# Altium schematic sheet MIXR Input System - Buffer.SchDoc
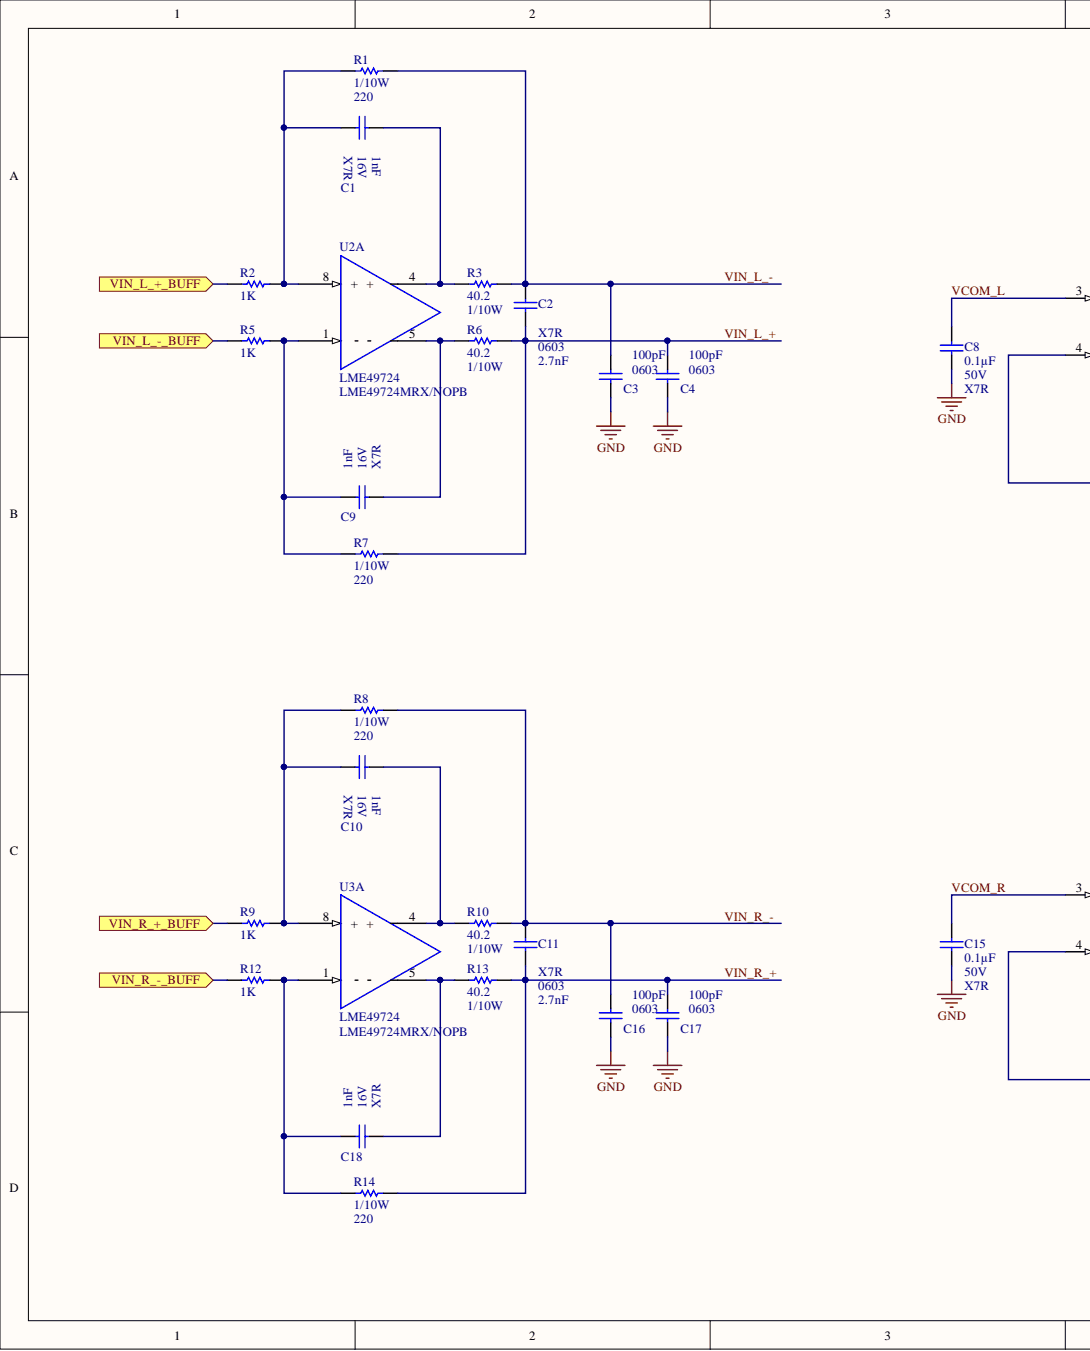
[diagram p0: MIXR Input System - Buffer.SchDoc, left]
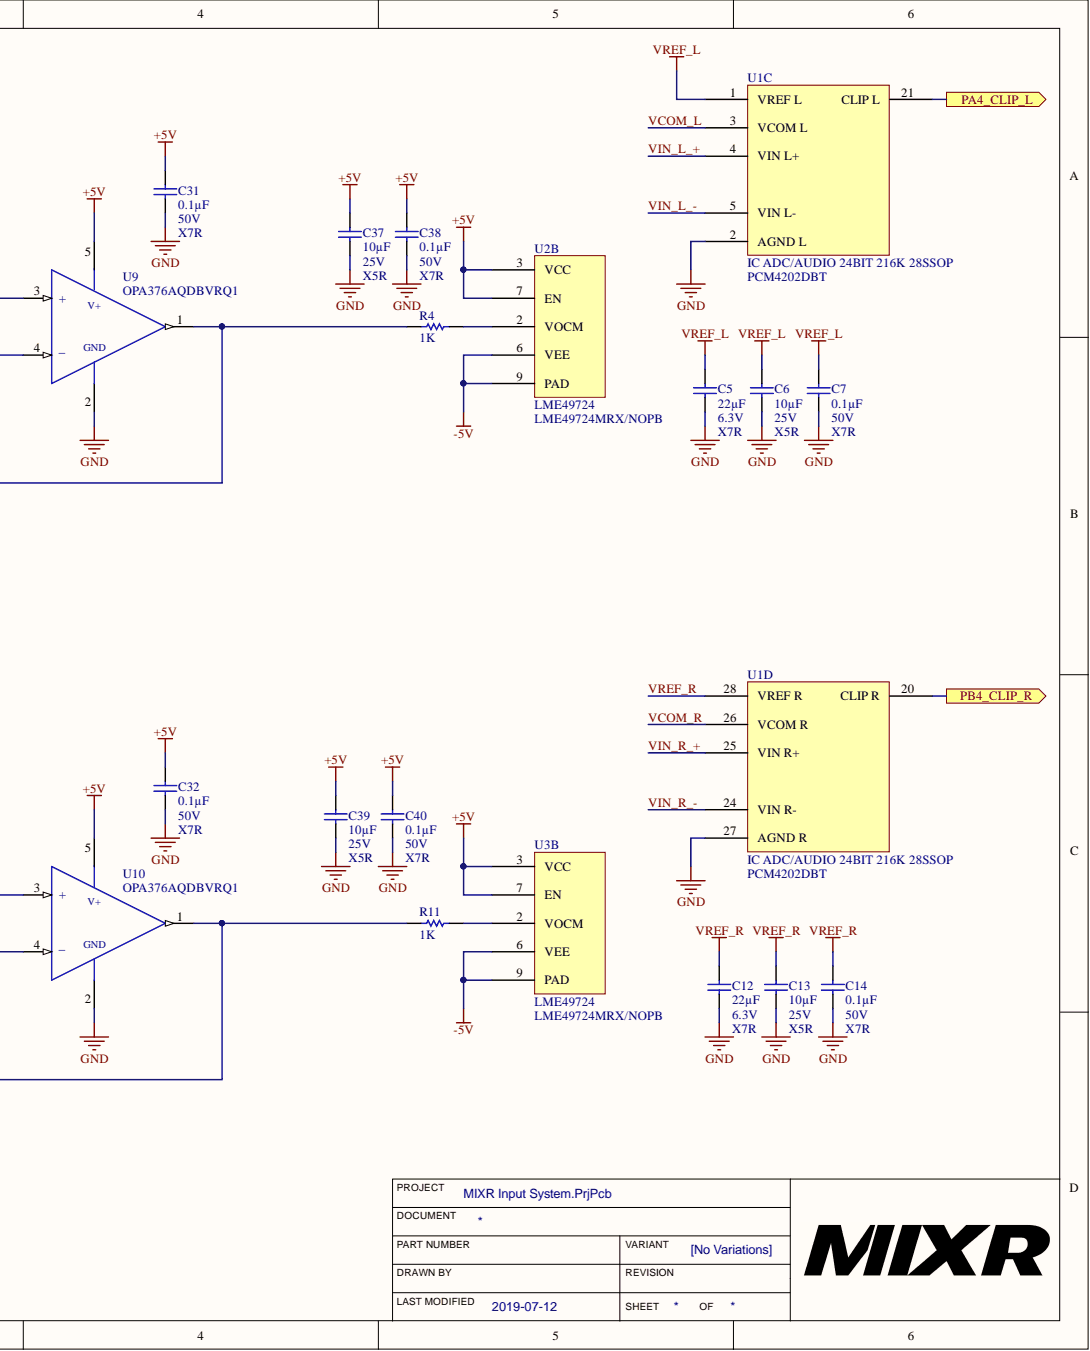
[diagram p1: MIXR Input System - Buffer.SchDoc, right]

## Parts
C1  CAP CER 1NF 16V 10% X7R 0603  [CAP, 0603]  (value not drawn)
C2  Capacitance: 2.7nF  Case/Package: 0603  Dielectric: X7R  [CAP, 0603]  (hidden comment: CAP CER 2700PF 50V X7R 0603)
C3  CAP CER 100PF 50V C0G/NP0 0603  [CAP, 0603]  (value not drawn)
C4  Capacitance: 100pF  Case/Package: 0603  [CAP, 0603]  (hidden comment: CAP CER 100PF 50V C0G/NP0 0603)
C5  Capacitance: 22µF  Temperature Coefficient: X7R  Voltage: 6.3V  [CAP, 1206]  (hidden comment: CAP CER 22UF 6.3V ±10% X7R 1206)
C6  Capacitance: 10µF  Temperature Coefficient: X5R  Voltage: 25V  [CAP, 0603]  (hidden comment: CAP CER 10uF 25V 20% X5R 0603)
C7  Capacitance: 0.1µF  Temperature Coefficient: X7R  Voltage: 50V  [CAP, 0603]  (hidden comment: CAP CER 0.1UF 50V 10% X7R 0603)
C8  Capacitance: 0.1µF  Temperature Coefficient: X7R  Voltage: 50V  [CAP, 0603]  (hidden comment: CAP CER 0.1UF 50V 10% X7R 0603)
C9  CAP CER 1NF 16V 10% X7R 0603  [CAP, 0603]  (value not drawn)
C10  CAP CER 1NF 16V 10% X7R 0603  [CAP, 0603]  (value not drawn)
C11  Capacitance: 2.7nF  Case/Package: 0603  Dielectric: X7R  [CAP, 0603]  (hidden comment: CAP CER 2700PF 50V X7R 0603)
C12  Capacitance: 22µF  Temperature Coefficient: X7R  Voltage: 6.3V  [CAP, 1206]  (hidden comment: CAP CER 22UF 6.3V ±10% X7R 1206)
C13  Capacitance: 10µF  Temperature Coefficient: X5R  Voltage: 25V  [CAP, 0603]  (hidden comment: CAP CER 10uF 25V 20% X5R 0603)
C14  Capacitance: 0.1µF  Temperature Coefficient: X7R  Voltage: 50V  [CAP, 0603]  (hidden comment: CAP CER 0.1UF 50V 10% X7R 0603)
C15  Capacitance: 0.1µF  Temperature Coefficient: X7R  Voltage: 50V  [CAP, 0603]  (hidden comment: CAP CER 0.1UF 50V 10% X7R 0603)
C16  CAP CER 100PF 50V C0G/NP0 0603  [CAP, 0603]  (value not drawn)
C17  Capacitance: 100pF  Case/Package: 0603  [CAP, 0603]  (hidden comment: CAP CER 100PF 50V C0G/NP0 0603)
C18  CAP CER 1NF 16V 10% X7R 0603  [CAP, 0603]  (value not drawn)
C31  Capacitance: 0.1µF  Voltage: 50V  [CAP, 0603]  (hidden comment: CAP CER 0.1UF 50V 10% X7R 0603)
C32  Capacitance: 0.1µF  Voltage: 50V  [CAP, 0603]  (hidden comment: CAP CER 0.1UF 50V 10% X7R 0603)
C37  Capacitance: 10µF  Temperature Coefficient: X5R  Voltage: 25V  [CAP, 0603]  (hidden comment: CAP CER 10uF 25V 20% X5R 0603)
C38  Capacitance: 0.1µF  Temperature Coefficient: X7R  Voltage: 50V  [CAP, 0603]  (hidden comment: CAP CER 0.1UF 50V 10% X7R 0603)
C39  Capacitance: 10µF  Temperature Coefficient: X5R  Voltage: 25V  [CAP, 0603]  (hidden comment: CAP CER 10uF 25V 20% X5R 0603)
C40  Capacitance: 0.1µF  Temperature Coefficient: X7R  Voltage: 50V  [CAP, 0603]  (hidden comment: CAP CER 0.1UF 50V 10% X7R 0603)
R1  Power (Watts): 1/10W  [RES, 0603]  (hidden comment: RES 220 OHM 1% 1/10W 0603)
R2  1K  [RES, 0603]
R3  Resistance: 40.2  [RES, 0603]  (hidden comment: RES 40.2 OHM 0.5% 1/10W 0603)
R4  1K  [RES, 0603]
R5  1K  [RES, 0603]
R6  Power: 1/10W  Resistance: 40.2  [RES, 0603]  (hidden comment: RES 40.2 OHM 0.5% 1/10W 0603)
R7  Power (Watts): 1/10W  [RES, 0603]  (hidden comment: RES 220 OHM 1% 1/10W 0603)
R8  Power (Watts): 1/10W  [RES, 0603]  (hidden comment: RES 220 OHM 1% 1/10W 0603)
R9  1K  [RES, 0603]
R10  Resistance: 40.2  [RES, 0603]  (hidden comment: RES 40.2 OHM 0.5% 1/10W 0603)
R11  1K  [RES, 0603]
R12  1K  [RES, 0603]
R13  Power: 1/10W  Resistance: 40.2  [RES, 0603]  (hidden comment: RES 40.2 OHM 0.5% 1/10W 0603)
R14  Power (Watts): 1/10W  [RES, 0603]  (hidden comment: RES 220 OHM 1% 1/10W 0603)
U1  IC ADC/AUDIO 24BIT 216K 28SSOP  Manufacturer Part Number: PCM4202DBT  [IC ADC 24 BIT AUDIO 216 KHZ SSOP-28]  (parts C,D)
U2  LME49724  Manufacturer Part Number: LME49724MRX/NOPB  [IC OP AMP AUDIO LME49724 8-SOIC]  (parts A,B)
U3  LME49724  Manufacturer Part Number: LME49724MRX/NOPB  [IC OP AMP AUDIO LME49724 8-SOIC]  (parts A,B)
U9  Manufacturer Part Number: OPA376AQDBVRQ1  [IC OP AMP GP RR 10MHZ SOT-23-5]  (hidden comment: IC OP AMP GEN PURPOSE RR 5.5MHZ SOT-23-5)
U10  Manufacturer Part Number: OPA376AQDBVRQ1  [IC OP AMP GP RR 10MHZ SOT-23-5]  (hidden comment: IC OP AMP GEN PURPOSE RR 5.5MHZ SOT-23-5)

## Ports
PA4_CLIP_L, PB4_CLIP_R, VIN_L_+_BUFF, VIN_L_-_BUFF, VIN_R_+_BUFF, VIN_R_-_BUFF

## Nets
+5V: C31.1 C32.1 C37.1 C38.1 C39.1 C40.1 U2.3(VCC) U2.7(EN) U3.3(VCC) U3.7(EN) U9.5 U10.5
-5V: U2.6(VEE) U2.9(PAD) U3.6(VEE) U3.9(PAD)
GND: C3.2 C4.2 C5.2 C6.2 C7.2 C8.2 C12.2 C13.2 C14.2 C15.2 C16.2 C17.2 C31.2 C32.2 C37.2 C38.2 C39.2 C40.2 U1.2(AGND_L) U1.27(AGND_R) U9.2 U10.2
VREF_L: C5.1 C6.1 C7.1 U1.1(VREF_L)
PA4_CLIP_L: U1.21(CLIP_L) ; port PA4_CLIP_L
PB4_CLIP_R: U1.20(CLIP_R) ; port PB4_CLIP_R
VCOM_L: C8.1 U1.3(VCOM_L) U9.3(+)
VCOM_R: C15.1 U1.26(VCOM_R) U10.3(+)
VIN_L_+: C2.2 C4.1 R6.2 R7.1 U1.4(VIN_L+)
VIN_L_-: C2.1 C3.1 R1.2 R3.2 U1.5(VIN_L-)
VIN_R_+: C11.2 C17.1 R13.2 R14.1 U1.25(VIN_R+)
VIN_R_-: C11.1 C16.1 R8.2 R10.2 U1.24(VIN_R-)
VREF_R: C12.1 C13.1 C14.1 U1.28(VREF_R)
NetC1_1: C1.1 R3.1 U2.4(+)
NetC1_2: C1.2 R1.1 R2.2 U2.8(+)
NetC9_1: C9.1 R5.2 R7.2 U2.1
NetC9_2: C9.2 R6.1 U2.5
NetC10_1: C10.1 R10.1 U3.4(+)
NetC10_2: C10.2 R8.1 R9.2 U3.8(+)
NetC18_1: C18.1 R12.2 R14.2 U3.1
NetC18_2: C18.2 R13.1 U3.5
NetR2_1: R2.1
NetR4_1: R4.1 U9.1 U9.4
NetR4_2: R4.2 U2.2(VOCM)
NetR5_1: R5.1
NetR9_1: R9.1
NetR11_1: R11.1 U10.1 U10.4
NetR11_2: R11.2 U3.2(VOCM)
NetR12_1: R12.1

## Library data of the parts
C1 C9 C10 C18 = CAP CER 1NF 16V 10% X7R 0603: 1000pF ±10% 16V Ceramic Capacitor X7R 0603 (1608 Metric); Manufacturer: KEMET; Manufacturer Part Number: C0603C102K4RECAUTO; Component Kind: Standard; ComponentLink1Description: https://api.kemet.com/component-edge/download/datasheet/C0603C102K4RECAUTO.pdf?src-supplier=Digi-Key; ComponentLink1URL: https://api.kemet.com/component-edge/download/datasheet/C0603C102K4RECAUTO.pdf?src-supplier=Digi-Key; Pricing 1: 1 = 0.19000, 10 = 0.13200, 100 = 0.06210, 500 = 0.04356, 1000 = 0.03606 (USD); RoHS: No; Stock 1: 7692; Supplier 1: Digi-Key; Supplier Part Number 1: 399-17878-1-ND; Library Name: Schematic Diagrams.SchLib
C2 C11 = CAP CER 2700PF 50V X7R 0603: 2700pF ±10% 50V Ceramic Capacitor X7R 0603 (1608 Metric); Manufacturer: KEMET; Manufacturer Part Number: C0603C272K5RACTU; Case Code (Imperial): 0603; Case Code (Metric): 1608; Component Kind: Standard; ComponentLink1Description: https://datasheet.ciiva.com/18192/getdatasheetpartid-321287-18192955.pdf?src-supplier=Verical; ComponentLink1URL: https://datasheet.ciiva.com/18192/getdatasheetpartid-321287-18192955.pdf?src-supplier=Verical; ComponentLink2Description: https://datasheet.ciiva.com/7823/1633156-7823726.pdf?src-supplier=Element14; ComponentLink2URL: https://datasheet.ciiva.com/7823/1633156-7823726.pdf?src-supplier=Element14; ComponentLink3Description: https://datasheet.ciiva.com/7823/1633156-7823727.pdf?src-supplier=Element14; ComponentLink3URL: https://datasheet.ciiva.com/7823/1633156-7823727.pdf?src-supplier=Element14; Depth: 0.8mm; Dielectric Material: Ceramic; Height: 0.8mm; Lead Pitch: 0.7mm; Length: 1.6mm; Max Operating Temperature: 125°C; Min Operating Temperature: -55°C; Mount: Surface Mount; Number of Pins: 2; Package Quantity: 1; Packaging: Tape and Reel; Pricing 1: 1 = 0.11000, 10 = 0.08200, 100 = 0.03660, 500 = 0.02614, 1000 = 0.02054 (USD); Radiation Hardening: No; REACH SVHC: No SVHC; RoHS: Yes; Stock 1: 166011; Supplier 1: Digi-Key; Supplier Part Number 1: 399-7890-1-ND; Termination: SMD/SMT; Thickness: 0.034inch; Tolerance: 10%; Voltage: 50V; Voltage Rating: 50V; Voltage Rating (DC): 50V; Width: 0.031inch; Library Name: Schematic Diagrams.SchLib
C3 C4 C16 C17 = CAP CER 100PF 50V C0G/NP0 0603: 100pF ±1% 50V Ceramic Capacitor C0G, NP0 0603 (1608 Metric); Manufacturer: Kyocera AVX; Manufacturer Part Number: 06035A101FAT2A; Case Code (Imperial): 0603; Case Code (Metric): 1608; Component Kind: Standard; ComponentLink1Description: https://datasheet.ciiva.com/22682/1796416-22682854.pdf; ComponentLink1URL: https://datasheet.ciiva.com/22682/1796416-22682854.pdf; ComponentLink2Description: https://datasheet.ciiva.com/150/ccog-150746.pdf; ComponentLink2URL: https://datasheet.ciiva.com/150/ccog-150746.pdf; ComponentLink3Description: https://datasheet.ciiva.com/150/ccog-150747.pdf; ComponentLink3URL: https://datasheet.ciiva.com/150/ccog-150747.pdf; ComponentLink4Description: https://datasheet.ciiva.com/150/ccog-150748.pdf; ComponentLink4URL: https://datasheet.ciiva.com/150/ccog-150748.pdf; ComponentLink5Description: https://datasheet.ciiva.com/28585/1796416-28585980.pdf; ComponentLink5URL: https://datasheet.ciiva.com/28585/1796416-28585980.pdf; ComponentLink6Description: https://datasheet.ciiva.com/16300/ccog-16300413.pdf; ComponentLink6URL: https://datasheet.ciiva.com/16300/ccog-16300413.pdf; ComponentLink7Description: https://datasheet.ciiva.com/17492/ccog-17492189.pdf; ComponentLink7URL: https://datasheet.ciiva.com/17492/ccog-17492189.pdf; Depth: 0.81mm; Dielectric: C0G; Height: 0.86mm; Lead Free: Lead Free; Length: 1.6mm; Max Operating Temperature: 125°C; Min Operating Temperature: -55°C; Mount: Surface Mount; Package Quantity: 1; Packaging: Tape and Reel; Pricing 1: 1=0.24, 10=0.161, 100=0.0814, 500=0.0557, 1000=0.0493 (USD); Radiation Hardening: No; REACH SVHC: No SVHC; Stock 1: 176069; Supplier 1: Digi-Key; Supplier Part Number 1: 478-6202-1-ND; Termination: SMD/SMT; Thickness: 0.035inch; Tolerance: 1%; Voltage: 50V; Voltage Rating: 50V; Voltage Rating (DC): 50V; Width: 0.81mm
  C3 C16: Capacitance: 100pF; Case/Package: 0603
C5 C12 = CAP CER 22UF 6.3V ±10% X7R 1206: 22µF ±10% 6.3V Ceramic Capacitor X7R 1206 (3216 Metric); Manufacturer 1: Murata Electronics North America; Manufacturer Part Number 1: GRM31CR70J226KE19L; Component Kind: Standard; ComponentLink1Description: http://www.murata.com/~/media/webrenewal/support/library/catalog/products/capacitor/mlcc/c02e.ashx; ComponentLink1URL: http://www.murata.com/~/media/webrenewal/support/library/catalog/products/capacitor/mlcc/c02e.ashx; Height - Seated (Max): -; Lead Spacing: -; Lead Style: -; Mounting Type: Surface Mount, MLCC; Operating Temperature: -55°C ~ 125°C; Package / Case: 1206 (3216 Metric); Packaging: Cut Tape (CT); Part Status: Active; Ratings: -; Size / Dimension: 0.126" L x 0.063" W (3.20mm x 1.60mm); Supplier 1: Digi-Key; Supplier Part Number 1: 490-6515-1-ND; Thickness (Max): 0.071" (1.80mm); Tolerance: ±10%
C6 C13 C37 C39 = CAP CER 10uF 25V 20% X5R 0603: 10µF ±20% 25V Ceramic Capacitor X5R 0603 (1608 Metric); Manufacturer 1: Murata Electronics North America; Manufacturer Part Number 1: GRM188R61E106MA73D; Component Kind: Standard; ComponentLink1Description: http://www.murata.com/~/media/webrenewal/support/library/catalog/products/capacitor/mlcc/c02e.ashx; ComponentLink1URL: http://www.murata.com/~/media/webrenewal/support/library/catalog/products/capacitor/mlcc/c02e.ashx; Height - Seated (Max): -; Lead Spacing: -; Lead Style: -; Mounting Type: Surface Mount, MLCC; Operating Temperature: -55°C ~ 85°C; Package / Case: 0603 (1608 Metric); Packaging: Cut Tape (CT); Part Status: Active; Ratings: -; Size / Dimension: 0.063" L x 0.031" W (1.60mm x 0.80mm); Supplier 1: Digi-Key; Supplier Part Number 1: 490-7202-1-ND; Thickness (Max): 0.031" (0.80mm); Tolerance: ±20%; Library Name: Schematic Diagrams.SchLib
C7 C8 C14 C15 C31 C32 C38 C40 = CAP CER 0.1UF 50V 10% X7R 0603: 0.10µF ±10% 50V Ceramic Capacitor X7R 0603 (1608 Metric); Manufacturer 1: AVX Corporation; Manufacturer Part Number 1: 06035C104KAT2A; Component Kind: Standard; ComponentLink1Description: http://datasheets.avx.com/X7RDielectric.pdf; ComponentLink1URL: http://datasheets.avx.com/X7RDielectric.pdf; Height - Seated (Max): -; Lead Spacing: -; Lead Style: -; Mounting Type: Surface Mount, MLCC; Operating Temperature: -55°C ~ 125°C; Package / Case: 0603 (1608 Metric); Packaging: Cut Tape (CT); Part Status: Active; Ratings: -; Size / Dimension: 0.063" L x 0.032" W (1.60mm x 0.81mm); Supplier 1: Digi-Key; Supplier Part Number 1: 478-5052-1-ND; Thickness (Max): 0.035" (0.90mm); Tolerance: ±10%; Library Name: Schematic Diagrams.SchLib
  C31 C32: Temperature Coefficient: X7R
R1 R7 R8 R14 = RES 220 OHM 1% 1/10W 0603: 220 Ohms ±1% 0.1W, 1/10W Chip Resistor 0603 (1608 Metric) Moisture Resistant Thick Film; Manufacturer: Yageo; Manufacturer Part Number: RC0603FR-07220RL; Resistance: 220; Temperature Coefficient: ±100ppm/°C; Category: Resistors; Component Kind: Standard; ComponentLink1Description: http://www.yageo.com/documents/recent/PYu-RC_Group_51_RoHS_L_8.pdf; ComponentLink1URL: http://www.yageo.com/documents/recent/PYu-RC_Group_51_RoHS_L_8.pdf; Composition: Thick Film; Failure Rate: -; Features: Moisture Resistant; Height - Seated (Max): 0.022" (0.55mm); Operating Temperature: -55°C ~ 155°C; Package / Case: 0603 (1608 Metric); Packaging: Cut Tape (CT); Part Status: Active; Pricing 1: 1=0.15, 10=0.022, 100=0.0088, 1000=0.00392, 2500=0.0034 (CAD); RoHS: RoHS Compliant; Size / Dimension: 0.063" L x 0.032" W (1.60mm x 0.80mm); Stock 1: 1318812; Supplier 1: Digi-Key; Supplier Device Package: 0603; Supplier Part Number 1: 311-220HRCT-ND; Tolerance: ±1%; Library Name: Schematic Diagrams.SchLib
R2 R4 R5 R9 R11 R12 = RES 1K OHM 5% 1/10W 0603: 1k Ohm ±5% 0.1W, 1/10W Chip Resistor 0603 (1608 Metric); Manufacturer: Yageo; Manufacturer Part Number: RC0603JR-071KL; Temperature Coefficient: ±100ppm/°C; Category: Resistors; Component Kind: Standard; ComponentLink1Description: http://www.yageo.com/documents/recent/PYu-RC_Group_51_RoHS_L_7.pdf; ComponentLink1URL: http://www.yageo.com/documents/recent/PYu-RC_Group_51_RoHS_L_7.pdf; Composition: Thick Film; Failure Rate: -; Features: Moisture Resistant; Height - Seated (Max): 0.022" (0.55mm); Operating Temperature: -55°C ~ 155°C; Package / Case: 0603 (1608 Metric); Packaging: Cut Tape (CT); Part Status: Active; Power (Watts): 0.1W, 1/10W; Pricing 1: 1=0.15, 10=0.017, 100=0.0071, 1000=0.0032, 2500=0.00278 (CAD); Resistance (Ohms): 1k; RoHS: RoHS Compliant; Size / Dimension: 0.063" L x 0.031" W (1.60mm x 0.80mm); Stock 1: 4415240; Supplier 1: Digi-Key; Supplier Device Package: 0603; Supplier Part Number 1: 311-1.0KGRCT-ND; Tolerance: ±5%
  R2 R5 R9 R12: Library Name: Schematic Diagrams.SchLib
R3 R6 R10 R13 = RES 40.2 OHM 0.5% 1/10W 0603: RES SMD 40.2 OHM 0.5% 1/10W 0603; Manufacturer 1: Yageo; Manufacturer Part Number 1: RT0603DRE0740R2L; Temperature Coefficient: ±50ppm/°C; Component Kind: Standard; ComponentLink1Description: http://www.yageo.com/documents/recent/PYu-RT_1-to-0.01_RoHS_L_7.pdf; ComponentLink1URL: http://www.yageo.com/documents/recent/PYu-RT_1-to-0.01_RoHS_L_7.pdf; Composition: Thin Film; Failure Rate: -; Height - Seated (Max): 0.022" (0.55mm); Operating Temperature: -55°C ~ 155°C; Package / Case: 0603 (1608 Metric); Packaging: Cut Tape (CT); Part Status: Active; Size / Dimension: 0.063" L x 0.031" W (1.60mm x 0.80mm); Supplier 1: Digi-Key; Supplier Device Package: 0603; Supplier Part Number 1: 311-2576-1-ND; Tolerance: ±0.5%; Library Name: Schematic Diagrams.SchLib
  R3 R10: Power: 1/10W
U1 = IC ADC 24 BIT AUDIO 216KHZ SSOP-28: ADC, Audio 24 bit 216k DSP, PCM 28-SSOP; Manufacturer: Texas Instruments; Conversion Rate: 216KS/s; Interface: Serial; Max Power Dissipation: 408mW; Max Supply Voltage: 5.25V; Min Supply Voltage: 4.75V; Nominal Supply Current: 55mA; Number of A/D Converters: 2; Number of Analog Inputs: 1; Number of Bits: 24; Number of DAC Channels: 0; Operating Supply Voltage: 5V; Polarity: Bipolar; Power Consumption: 338mW; Resolution: 24b; Supply Type: Analog; Case/Package: SSOP; Component Kind: Standard; ComponentLink1Description: https://datasheet.ciiva.com/25988/sbas290b-25988737.pdf?src-supplier=Avnet+Europe; ComponentLink1URL: https://datasheet.ciiva.com/25988/sbas290b-25988737.pdf?src-supplier=Avnet+Europe; ComponentLink2Description: https://datasheet.ciiva.com/41460/001186374-da-01-en-ic-adc-stereo-24b-pcm4202dbt-ssop-28-tid-41460403.pdf?src-supplier=Conrad; ComponentLink2URL: https://datasheet.ciiva.com/41460/001186374-da-01-en-ic-adc-stereo-24b-pcm4202dbt-ssop-28-tid-41460403.pdf?src-supplier=Conrad; ComponentLink3Description: https://datasheet.ciiva.com/26800/pcm4202dbt-26800794.pdf?src-supplier=IIIC; ComponentLink3URL: https://datasheet.ciiva.com/26800/pcm4202dbt-26800794.pdf?src-supplier=IIIC; ComponentLink4Description: https://datasheet.ciiva.com/29896/sbas290b-29896124.pdf?src-supplier=Avnet; ComponentLink4URL: https://datasheet.ciiva.com/29896/sbas290b-29896124.pdf?src-supplier=Avnet; ComponentLink5Description: https://datasheet.ciiva.com/1307/pcm4202-1307121.pdf?src-supplier=Digi-Key; ComponentLink5URL: https://datasheet.ciiva.com/1307/pcm4202-1307121.pdf?src-supplier=Digi-Key; ComponentLink6Description: https://datasheet.ciiva.com/1307/pcm4202-1307122.pdf?src-supplier=Digi-Key; ComponentLink6URL: https://datasheet.ciiva.com/1307/pcm4202-1307122.pdf?src-supplier=Digi-Key; ComponentLink7Description: https://datasheet.ciiva.com/1307/pcm4202-1307123.pdf?src-supplier=Digi-Key; ComponentLink7URL: https://datasheet.ciiva.com/1307/pcm4202-1307123.pdf?src-supplier=Digi-Key; ComponentLink8Description: https://datasheet.ciiva.com/15147/getdatasheetpartid-171631-15147899.pdf?src-supplier=Verical; ComponentLink8URL: https://datasheet.ciiva.com/15147/getdatasheetpartid-171631-15147899.pdf?src-supplier=Verical; Height: 1.95mm; Lead Free: Lead Free; Length: 10.2mm; Max Operating Temperature: 70°C; Min Operating Temperature: -10°C; Mount: Surface Mount; Number of ADC Channels: 2; Number of Converters: 2; Number of Elements: 2; Number of Pins: 28; Package Quantity: 250; Packaging: Tape and Reel; Power Dissipation: 408mW; Pricing 1: 1 = 9.85000, 10 = 8.89800, 100 = 7.36660 (USD); Radiation Hardening: No; REACH SVHC: No SVHC; RoHS: Yes; Sampling Rate: 216KS/s; Stock 1: 5943; Supplier 1: Digi-Key; Supplier Part Number 1: 296-17438-1-ND; Weight: 0.008529oz; Width: 5.3mm; Library Name: Schematic Diagrams.SchLib
U2 U3 = IC OP AMP AUDIO LME49724 8-SOIC: Audio Amplifier 1 Circuit Differential 8-SO PowerPad; Manufacturer: TI National Semiconductor; Common Mode Rejection Ratio: 95dB; Gain Bandwidth Product: 50MHz; Input Bias Current: 60nA; Input Offset Voltage (Vos): 200uV; Max Dual Supply Voltage: 18V; Max Supply Voltage: 36V; Min Dual Supply Voltage: 2.5V; Min Supply Voltage: 5V; Number of Channels: 1; Operating Supply Current: 10mA; Output Current: 80mA; Slew Rate: 18V/µs; Voltage Gain: 125dB; Component Kind: Standard; ComponentLink1Description: https://datasheet.ciiva.com/29638/lme49724-29638149.pdf?src-supplier=Digi-Key; ComponentLink1URL: https://datasheet.ciiva.com/29638/lme49724-29638149.pdf?src-supplier=Digi-Key; ComponentLink2Description: https://datasheet.ciiva.com/29638/lme49724-29638731.pdf?src-supplier=Digi-Key; ComponentLink2URL: https://datasheet.ciiva.com/29638/lme49724-29638731.pdf?src-supplier=Digi-Key; ComponentLink3Description: https://datasheet.ciiva.com/1818/lme49724-1818445.pdf?src-supplier=Digi-Key; ComponentLink3URL: https://datasheet.ciiva.com/1818/lme49724-1818445.pdf?src-supplier=Digi-Key; Contact Plating: Tin; Lead Free: Lead Free; Max Operating Temperature: 85°C; Min Operating Temperature: -40°C; Mount: Surface Mount; Number of Circuits: 1; Number of Elements: 1; Number of Pins: 8; Output Current per Channel: 80mA; Package Quantity: 2500; Packaging: Tape and Reel; Power Supply Rejection Ratio (PSRR): 95dB; Pricing 1: 1 = 2.67000, 10 = 2.40200, 100 = 1.93060, 500 = 1.58620, 1000 = 1.31428 (USD); Radiation Hardening: No; RoHS: Yes; Stock 1: 17947; Supplier 1: Digi-Key; Supplier Part Number 1: 296-37390-1-ND; Unity Gain Bandwidth Product: 50MHz; Library Name: Schematic Diagrams.SchLib
U9 U10 = IC OP AMP GEN PURPOSE RR 5.5MHZ SOT-23-5: General Purpose Amplifier 1 Circuit Rail-to-Rail SOT-23-5; Manufacturer: Texas Instruments; Common Mode Rejection Ratio: 76dB; Input Bias Current: 10pA; Input Offset Voltage (Vos): 25uV; Max Supply Voltage: 5.5V; Min Supply Voltage: 2.2V; Nominal Supply Current: 950uA; Number of Channels: 1; Operating Supply Current: 760uA; Output Current: 30mA; Output Current per Channel: 50mA; Power Supply Rejection Ratio (PSRR): 106.02dB; Slew Rate: 2V/µs; Voltage Gain: 134dB; Case/Package: SOT-23-5; Component Kind: Standard; ComponentLink1Description: http://www.ti.com/lit/ds/sbos549/sbos549.pdf?src-supplier=Avnet; ComponentLink1URL: http://www.ti.com/lit/ds/sbos549/sbos549.pdf?src-supplier=Avnet; Gain Bandwidth Product: 5.5MHz; Lead Free: Lead Free; Max I/O Voltage: 25uV; Max Operating Temperature: 125°C; Min Operating Temperature: -40°C; Number of Circuits: 1; Number of Elements: 1; Number of Pins: 5; Package Quantity: 3000; Packaging: Tape and Reel; Pricing 1: 1 = 2.14000, 10 = 1.92200, 100 = 1.54450, 500 = 1.26896, 1000 = 1.05142 (USD); Radiation Hardening: No; RoHS: Yes; Stock 1: 26635; Supplier 1: Digi-Key; Supplier Part Number 1: 296-36701-1-ND; Unity Gain Bandwidth Product: 5.5MHz; Library Name: Schematic Diagrams.SchLib
